# Altium schematic sheet ATK-MW1278D.SchDoc
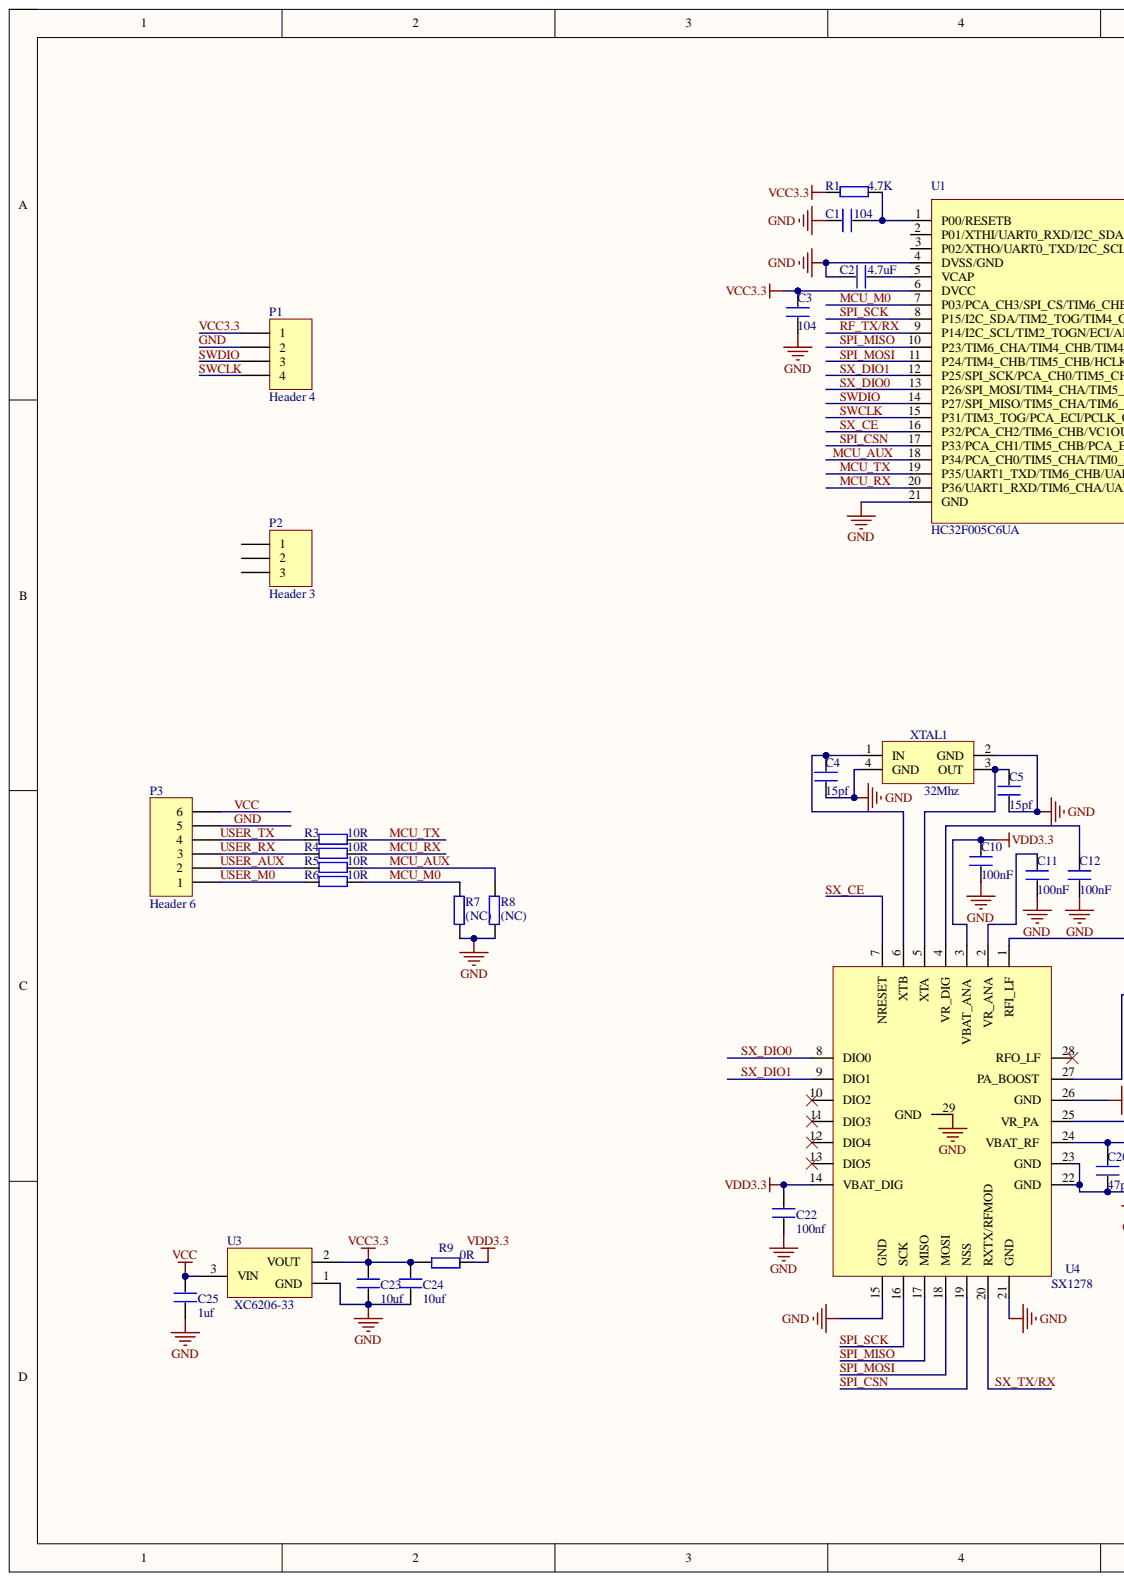
[diagram p0: ATK-MW1278D.SchDoc, left]
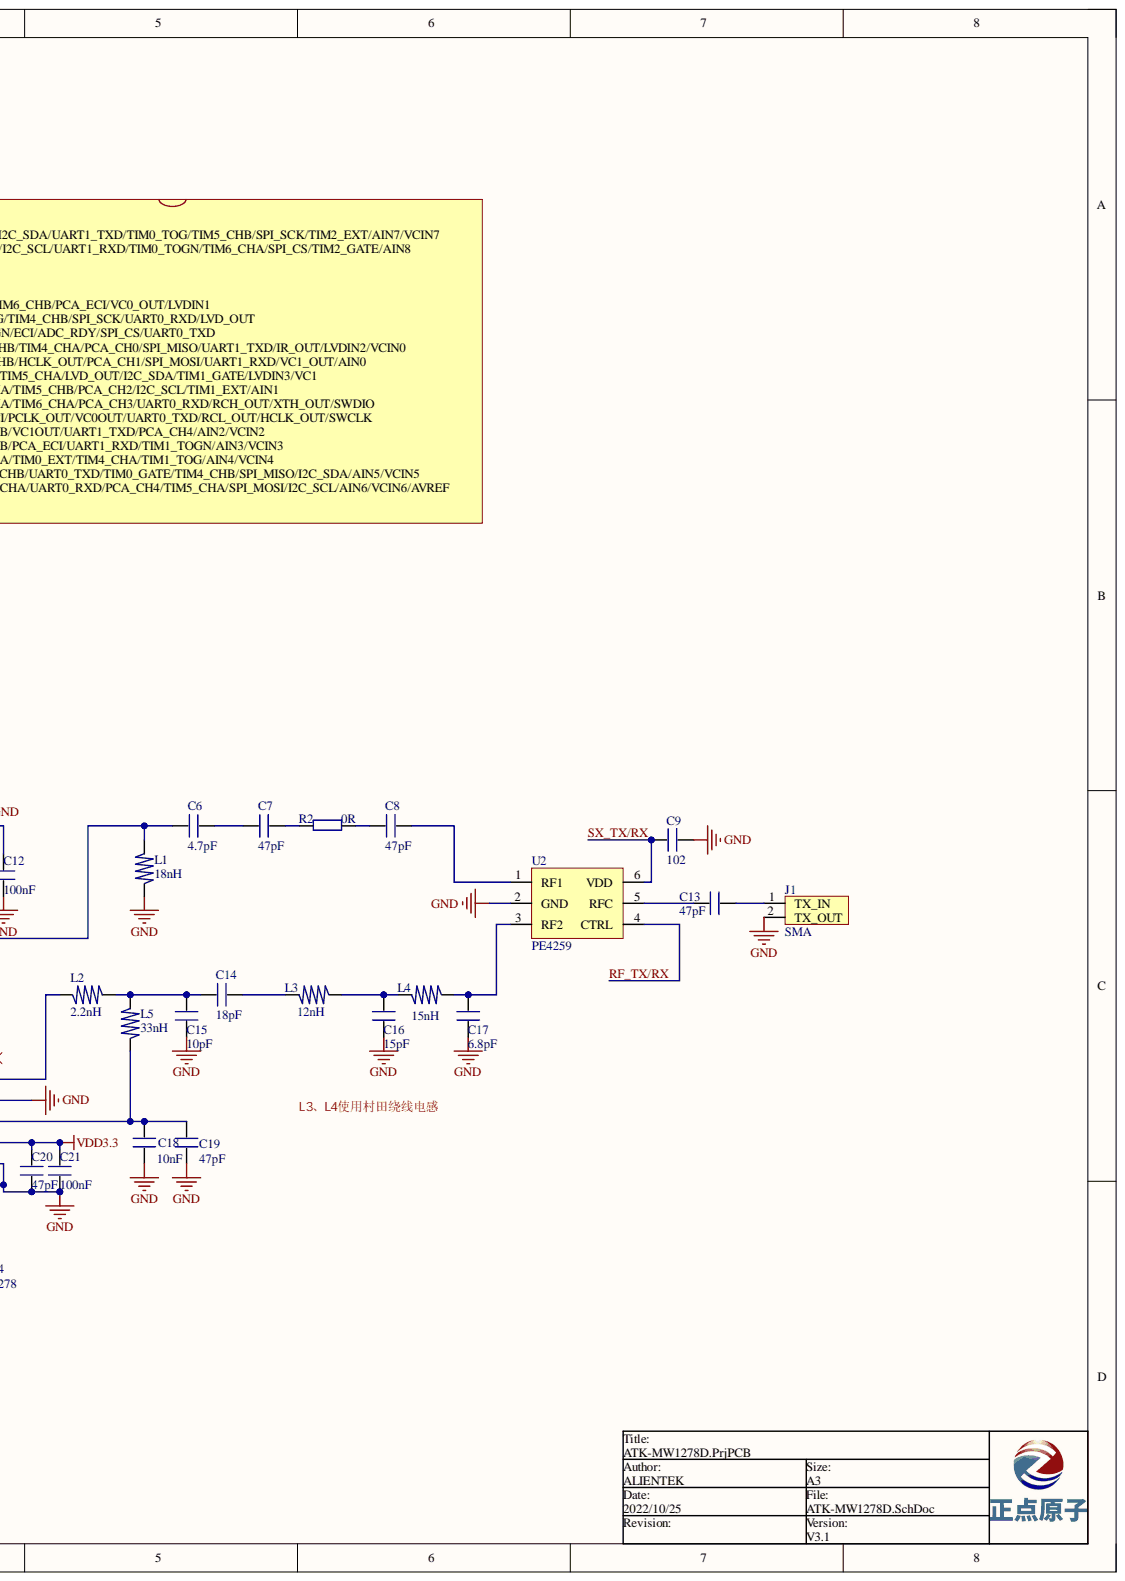
[diagram p1: ATK-MW1278D.SchDoc, right]

## Parts
C1  104  [0402]
C2  4.7uF  [0402]
C3  104  [0402]
C4  15pf  [0402]
C5  15pf  [0402]
C6  4.7pF  [0402]
C7  47pF  [0402]
C8  47pF  [0402]
C9  102  [0402]
C10  100nF  [0402]
C11  100nF  [0402]
C12  100nF  [0402]
C13  47pF  [0402]
C14  18pF  [0402]
C15  10pF  [0402]
C16  15pF  [0402]
C17  6.8pF  [0402]
C18  10nF  [0402]
C19  47pF  [0402]
C20  47pF  [0402]
C21  100nF  [0402]
C22  100nf  [0402]
C23  10uf  [0603P]
C24  10uf  [0603P]
C25  1uf  [0402]
J1  SMA  [SMA-2]
L1  18nH  [0402]
L2  2.2nH  [0402]
L3  12nH  [0402]
L4  15nH  [0402]
L5  33nH  [0402]
P1  Header 4  [HDR1X4]
P2  Header 3  [HDR1X3]
P3  Header 6  [HDR1X6]
R1  4.7K  [0402]
R2  0R  [0402]
R3  10R  [0402]
R4  10R  [0402]
R5  10R  [0402]
R6  10R  [0402]
R7  (NC)  [0402]
R8  (NC)  [0402]
R9  0R  [0402]
U1  HC32F005C6UA  [QFN20]
U2  PE4259  [SC70-6]
U3  XC6206-33  [SOT-23]
U4  SX1278  [LC-QFN28]
XTAL1  32Mhz  [XTAL3225]

## Nets
VDD3.3: C10.2 C20.2 C21.2 C22.2 R9.2 U4.3(VBAT_ANA) U4.14(VBAT_DIG) U4.24(VBAT_RF)
GND: C1.1 C2.2 C3.1 C4.1 C5.1 C9.1 C10.1 C11.1 C12.1 C15.2 C16.2 C17.2 C18.2 C19.2 C20.1 C21.1 C22.1 C23.1 C24.1 C25.1 J1.2 L1.1 P1.2 P3.5 R7.2 R8.2 U1.4(DVSS/GND) U1.21(GND) U2.2(GND) U3.1 U4.15(GND) U4.21(GND) U4.22(GND) U4.23(GND) U4.26(GND) U4.29(GND) XTAL1.2(GND) XTAL1.4(GND)
MCU_AUX: R5.1 R8.1 U1.18(P34/PCA_CH0/TIM5_CHA/TIM0_EXT/TIM4_CHA/TIM1_TOG/AIN4/VCIN4)
MCU_M0: R6.1 R7.1 U1.7(P03/PCA_CH3/SPI_CS/TIM6_CHB/PCA_ECI/VC0_OUT/LVDIN1)
MCU_RX: R4.1 U1.20(P36/UART1_RXD/TIM6_CHA/UART0_RXD/PCA_CH4/TIM5_CHA/SPI_MOSI/I2C_SCL/AIN6/VCIN6/AVREF)
MCU_TX: R3.1 U1.19(P35/UART1_TXD/TIM6_CHB/UART0_TXD/TIM0_GATE/TIM4_CHB/SPI_MISO/I2C_SDA/AIN5/VCIN5)
RF_TX/RX: U1.9(P14/I2C_SCL/TIM2_TOGN/ECI/ADC_RDY/SPI_CS/UART0_TXD) U2.4(CTRL)
SPI_CSN: U1.17(P33/PCA_CH1/TIM5_CHB/PCA_ECI/UART1_RXD/TIM1_TOGN/AIN3/VCIN3) U4.19(NSS)
SPI_MISO: U1.10(P23/TIM6_CHA/TIM4_CHB/TIM4_CHA/PCA_CH0/SPI_MISO/UART1_TXD/IR_OUT/LVDIN2/VCIN0) U4.17(MISO)
SPI_MOSI: U1.11(P24/TIM4_CHB/TIM5_CHB/HCLK_OUT/PCA_CH1/SPI_MOSI/UART1_RXD/VC1_OUT/AIN0) U4.18(MOSI)
SPI_SCK: U1.8(P15/I2C_SDA/TIM2_TOG/TIM4_CHB/SPI_SCK/UART0_RXD/LVD_OUT) U4.16(SCK)
SWCLK: P1.4 U1.15(P31/TIM3_TOG/PCA_ECI/PCLK_OUT/VC0OUT/UART0_TXD/RCL_OUT/HCLK_OUT/SWCLK)
SWDIO: P1.3 U1.14(P27/SPI_MISO/TIM5_CHA/TIM6_CHA/PCA_CH3/UART0_RXD/RCH_OUT/XTH_OUT/SWDIO)
SX_CE: U1.16(P32/PCA_CH2/TIM6_CHB/VC1OUT/UART1_TXD/PCA_CH4/AIN2/VCIN2) U4.7(NRESET)
SX_DIO0: U1.13(P26/SPI_MOSI/TIM4_CHA/TIM5_CHB/PCA_CH2/I2C_SCL/TIM1_EXT/AIN1) U4.8(DIO0)
SX_DIO1: U1.12(P25/SPI_SCK/PCA_CH0/TIM5_CHA/LVD_OUT/I2C_SDA/TIM1_GATE/LVDIN3/VC1) U4.9(DIO1)
SX_TX/RX: C9.2 U2.6(VDD) U4.20(RXTX/RFMOD)
USER_AUX: P3.2 R5.2
USER_M0: P3.1 R6.2
USER_RX: P3.3 R4.2
USER_TX: P3.4 R3.2
VCC: C25.2 P3.6 U3.3
VCC3.3: C3.2 C23.2 C24.2 P1.1 R1.2 R9.1 U1.6(DVCC) U3.2
NetC1_2: C1.2 R1.1 U1.1(P00/RESETB)
NetC2_1: C2.1 U1.5(VCAP)
NetC4_2: C4.2 U4.6(XTB) XTAL1.1(IN)
NetC5_2: C5.2 U4.5(XTA) XTAL1.3(OUT)
NetC6_1: C6.1 L1.2 U4.1(RFI_LF)
NetC6_2: C6.2 C7.1
NetC7_2: C7.2 R2.2
NetC8_1: C8.1 R2.1
NetC8_2: C8.2 U2.1(RF1)
NetC11_2: C11.2 U4.2(VR_ANA)
NetC12_2: C12.2 U4.4(VR_DIG)
NetC13_1: C13.1 U2.5(RFC)
NetC13_2: C13.2 J1.1
NetC14_1: C14.1 C15.1 L2.2 L5.2
NetC14_2: C14.2 L3.2
NetC16_1: C16.1 L3.1 L4.2
NetC17_1: C17.1 L4.1 U2.3(RF2)
NetC18_1: C18.1 C19.1 L5.1 U4.25(VR_PA)
NetL2_1: L2.1 U4.27(PA_BOOST)

Not connected: P2 1 2 3; U1 2(P01/XTHI/UART0_RXD/I2C_SDA/UART1_TXD/TIM0_TOG/TIM5_CHB/SPI_SCK/TIM2_EXT/AIN7/VCIN7) 3(P02/XTHO/UART0_TXD/I2C_SCL/UART1_RXD/TIM0_TOGN/TIM6_CHA/SPI_CS/TIM2_GATE/AIN8); U4 10(DIO2) 11(DIO3) 12(DIO4) 13(DIO5) 28(RFO_LF)

## Library data of the parts
C1-C25 = C: Component Kind: Standard
  C1: Library Name: WarShip V3 STM32103封装库.IntLib
  C2-C21: Library Name: ATK-2014-09-12111.IntLib
  C22-C25: Library Name: ALIENTEK_COMPONENT111.IntLib
J1 = SMA-2: Component Kind: Standard; Description: SMA-2; Library Name: ATK-2014-09-12111.IntLib
L1-L5 = L: Component Kind: Standard; Library Name: ALIENTEK_COMPONENT111.IntLib
P1 = Header 4: Header, 4-Pin; Component Kind: Standard; LatestRevisionDate: 17-Jul-2002; LatestRevisionNote: Re-released for DXP Platform.; Library Name: Miscellaneous Connectors.IntLib; Signal Integrity: Connector; Publisher: Altium Limited
P2 = Header 3: Header, 3-Pin; Component Kind: Standard; LatestRevisionDate: 17-Jul-2002; LatestRevisionNote: Re-released for DXP Platform.; Library Name: Miscellaneous Connectors.IntLib; Signal Integrity: Connector; Publisher: Altium Limited
P3 = Header 6: Header, 6-Pin; Component Kind: Standard; LatestRevisionDate: 17-Jul-2002; LatestRevisionNote: Re-released for DXP Platform.; Library Name: Miscellaneous Connectors.IntLib; Signal Integrity: Connector; Publisher: Altium Limited
R1-R9 = R: Component Kind: Standard
  R1: Library Name: WarShip V3 STM32103封装库.IntLib
  R2 R9: Library Name: ALIENTEK_COMPONENT111.IntLib
  R3-R8: Library Name: ATK-2014-09-12111.IntLib
U1 = HC32F005C6UA: HDSC华大半导体; Component Kind: Standard; Library Name: fantasylib.SchLib
U2 = PE4259: 射频开关; Component Kind: Standard; Library Name: fantasylib.SchLib
U3 = AIC1734-33: 3.3V LDO 300MA; Component Kind: Standard; Library Name: fantasylib.SchLib
U4 = SX1278: LoRa 433Mhz无线芯片; Component Kind: Standard; Library Name: fantasylib.SchLib
XTAL1 = XTAL-3325: Component Kind: Standard; Library Name: fantasylib.SchLib
